# Altium schematic sheet I2C_Isolator.SchDoc
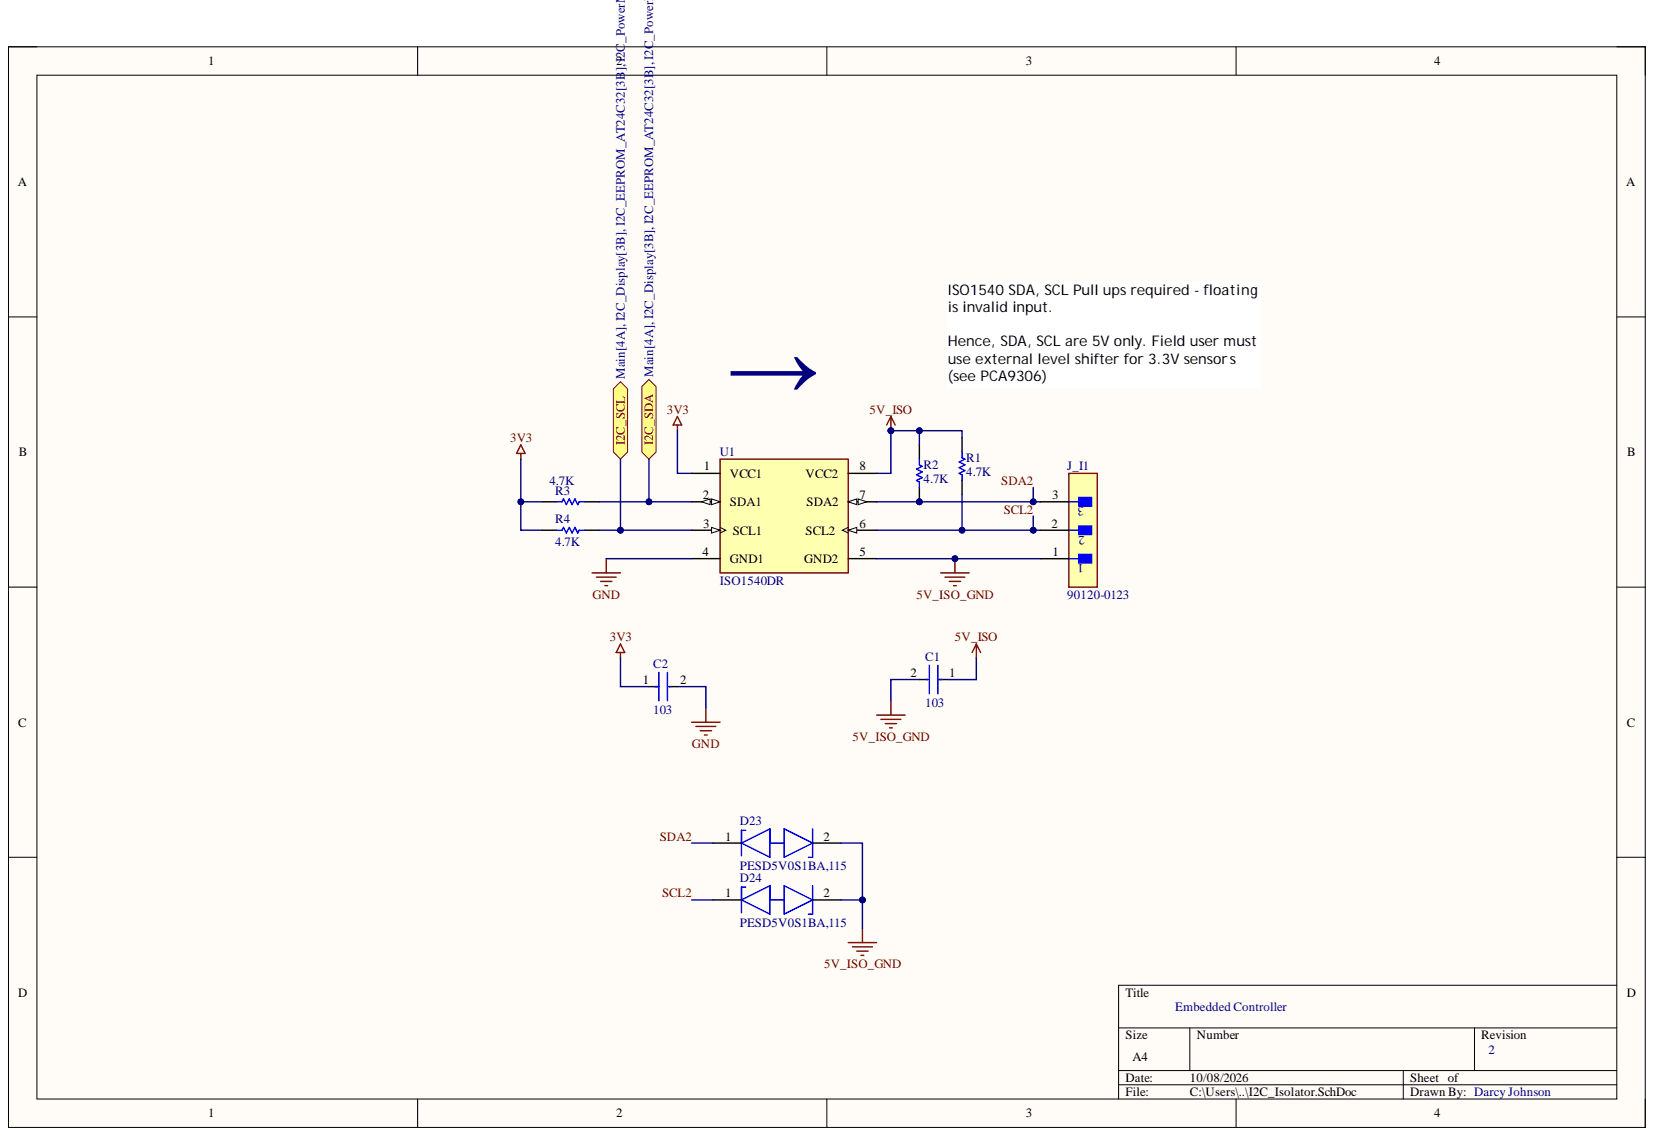
[diagram p0: I2C_Isolator.SchDoc]

## Parts
C1  103  [FP-CC0603-DA-MFG]
C2  103  [FP-CC0603-DA-MFG]
D23  PESD5V0S1BA,115  [FP-SOD323-CA-MFG]
D24  PESD5V0S1BA,115  [FP-SOD323-CA-MFG]
J_I1  90120-0123  [FP-90120-0123-MFG]
R1  4.7K  [RESC1608X55X25ML10T15]
R2  4.7K  [RESC1608X55X25ML10T15]
R3  4.7K  [RESC1608X55X25ML10T15]
R4  4.7K  [RESC1608X55X25ML10T15]
U1  ISO1540DR  [FP-D0008A-MFG]

## Ports
I2C_SCL, I2C_SDA

## Nets
3V3: C2.1 R3.2 R4.2 U1.1(VCC1)
5V_ISO: C1.1 R1.2 R2.2 U1.8(VCC2)
5V_ISO_GND: C1.2 D23.2 D24.2 J_I1.1 U1.5(GND2)
GND: C2.2 U1.4(GND1)
I2C_SCL: R4.1 U1.3(SCL1) ; port I2C_SCL
I2C_SDA: R3.1 U1.2(SDA1) ; port I2C_SDA
SCL2: D24.1 J_I1.2 R1.1 U1.6(SCL2)
SDA2: D23.1 J_I1.3 R2.1 U1.7(SDA2)

## Library data of the parts
C1 C2 = CMP-2000-05002-2: CAP CER 0.1UF 16V X7R 0603; Manufacturer: YAGEO; Manufacturer Part Number: CC0603KRX7R7BB104; Capacitance: 100 nF; Material: Ceramic; Temperature Coefficient: 15%; Tolerance: 0.1; Value: 100 nF; Automotive: No; Category: Cap; Component Kind: Standard; ComponentLink2Description: Datasheet; ComponentLink2URL: http://www.yageo.com/documents/recent/UPY-GPHC_X7R_6.3V-to-50V_18.pdf; Device Class L1: Passive Components; Device Class L2: Capacitors; Device Class L3: Ceramic Capacitors; Digikey Description: CAP CER 0.1UF 16V X7R 0603; Footprint Url: http://www.yageo.com/exep/pages/download/literatures/UPY-C_GEN_24.pdf; Height: 0.9mm; Lead Free: Yes; Library Name: Altium Content Vault; Mouser Description: Multilayer Ceramic Capacitor, CC Series, 0.1 - F, - 10%, X7R, 16 V, 0603 [1608 Metric]; Octopart: https://octopart.com/cc0603krx7r7bb104-yageo-192941; Package: CC0603-DA; RoHS: TRUE; Temperature: -55°C to +125°C; Temperature Characteristic: X7R; Temperature Range High: +125°C; Temperature Range Low: -55°C; Voltage: 16 V; Voltage Rating: 16 V
D23 D24 = CMP-14432-000001-1: TVS DIODE 5V 14V SOD323; Component Kind: Standard; Library Name: Altium Content Vault
J_I1 = CMP-04776-000237-1: CONN HEADER VERT 3POS 2.54MM; Contact Post Length: 2.9mm; Contact Resistance: 20mR; Current Rating: 3A; Gender: Male; Glow Wire Compliant: Non-compliant; Max Voltage Rating (DC): 350.35kV; Number of Circuits: 3; Voltage Rating: 350V; Color: Black; Component Kind: Standard; Contact Gender: Male; Contact Material: Brass; Contact Mating Length: 6.75mm; Contact Plating: Tin; Housing Color: Black; Housing Material: Polyester; Lead Free: Lead Free; Library Name: Altium Content Vault; Max Operating Temperature: 125°C; Min Operating Temperature: -55°C; Mount: Through Hole; Number of Contacts: 3; Number of Positions: 3; Number of Rows: 1; Orientation: Vertical; Packaging: Tray; Pitch: 2.54mm; Radiation Hardening: No; REACH SVHC: No SVHC; RoHS Compliant: Yes; Series: 90120; Termination: Solder; Voltage Rating (AC): 350V
R1-R4 = CMP-2000-05024-1: Chip Resistor, 4.7 KOhm, +/- 5%, 100 mW, -55 to 155 degC, 0603 (1608 Metric), RoHS, Tape and Reel; Manufacturer: Yageo; Power Rating: 100mW; Resistance: 4.7kOhms; Temperature Coefficient: 100PPM/C; Voltage Rating: 50V; Case Code - in: 0603; Case Code - mm: 1608; Component Kind: Standard; Datasheet URL: http://www.yageo.com/documents/recent/PYu-RC_Group_51_RoHS_L_04.pdf; Datasheet Version: Rev. 4, 02/2016; Library Name: Altium Content Vault; Manufacturer URL: http://www.yageo.com/; Operating Temperature Range: -55Cto+155C; Package Description: 2-Pin Surface Mount Device, Body 1.6 x 0.8 mm; Package Reference: 603; Packaging: Reel; Product: ThickFilmResistorsGeneralPurpose; Series: RC; Termination Style: SMD/SMT; Tolerance: 5%
U1 = CMP-04872-000011-1: DGTL ISO 2.5KV 2CH I2C 8SOIC; Manufacturer: Texas Instruments; Interface: I2C,SMBus,Other; Max Junction Temp: +136°C; Max Supply Voltage: 5.5V; Min Supply Voltage: 3V; Nominal Supply Current: 1.7-3.1mA; Standoff Height: 0.11mm; Ambient Temperature Range High: +125°C; Ambient Temperature Range Low: -40°C; Automotive: No; Category: IC; Component Kind: Standard; ComponentLink2Description: Datasheet; ComponentLink2URL: http://www.ti.com/lit/ds/symlink/iso1540.pdf; Device Class L1: Integrated Circuits (ICs); Device Class L2: Interface ICs; Device Class L3: unset; Digikey Description: DGTL ISO 2.5KV 2CH I2C 8SOIC; Height: 1.75mm; Ipc Land Pattern Name: SOIC127P600X175-8; Lead Free: Yes; Library Name: Altium Content Vault; Manufacturer Part Number: ISO1540DR; Mouser Description: Digital Isolators Low-Power,Bidirec I2C Iso; Octopart: https://octopart.com/iso1540dr-texas+instruments-24334113; Package: D0008A; RoHS: TRUE; Temperature: -40°C to +125°C; Temperature Range High: +125°C; Temperature Range Low: -40°C
